# Altium schematic sheet H_bridge.SchDoc
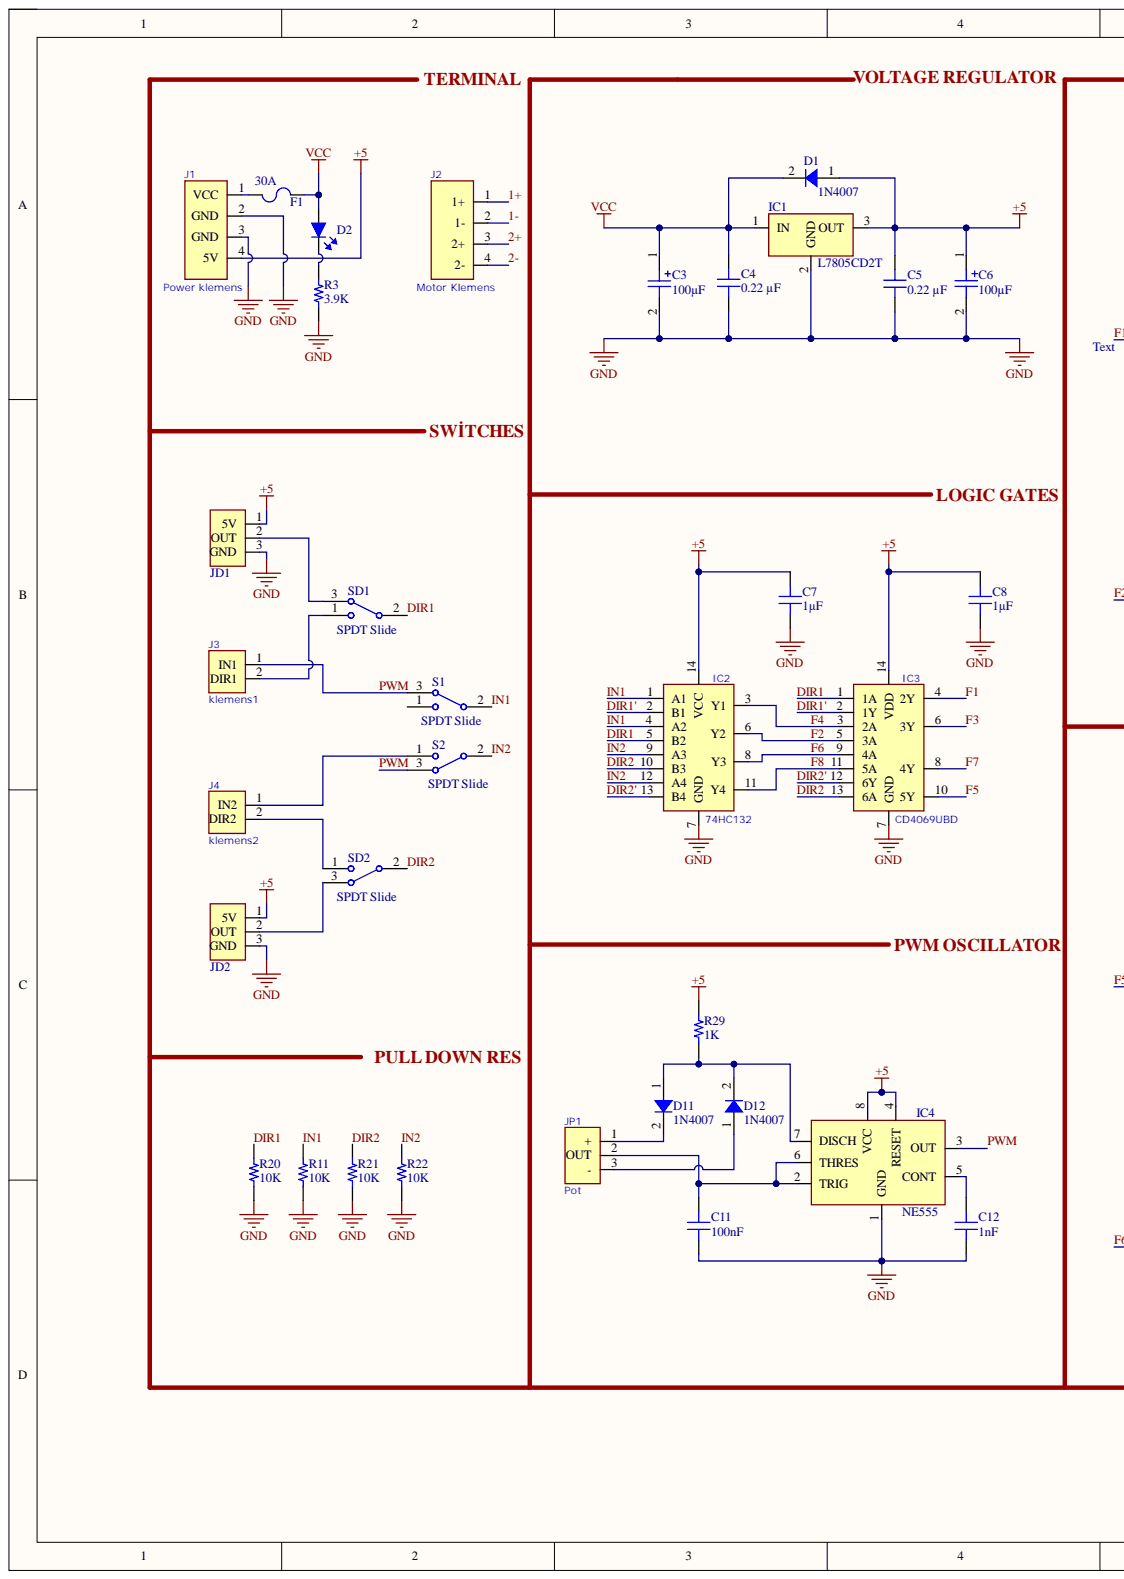
[diagram p0: H_bridge.SchDoc, left]
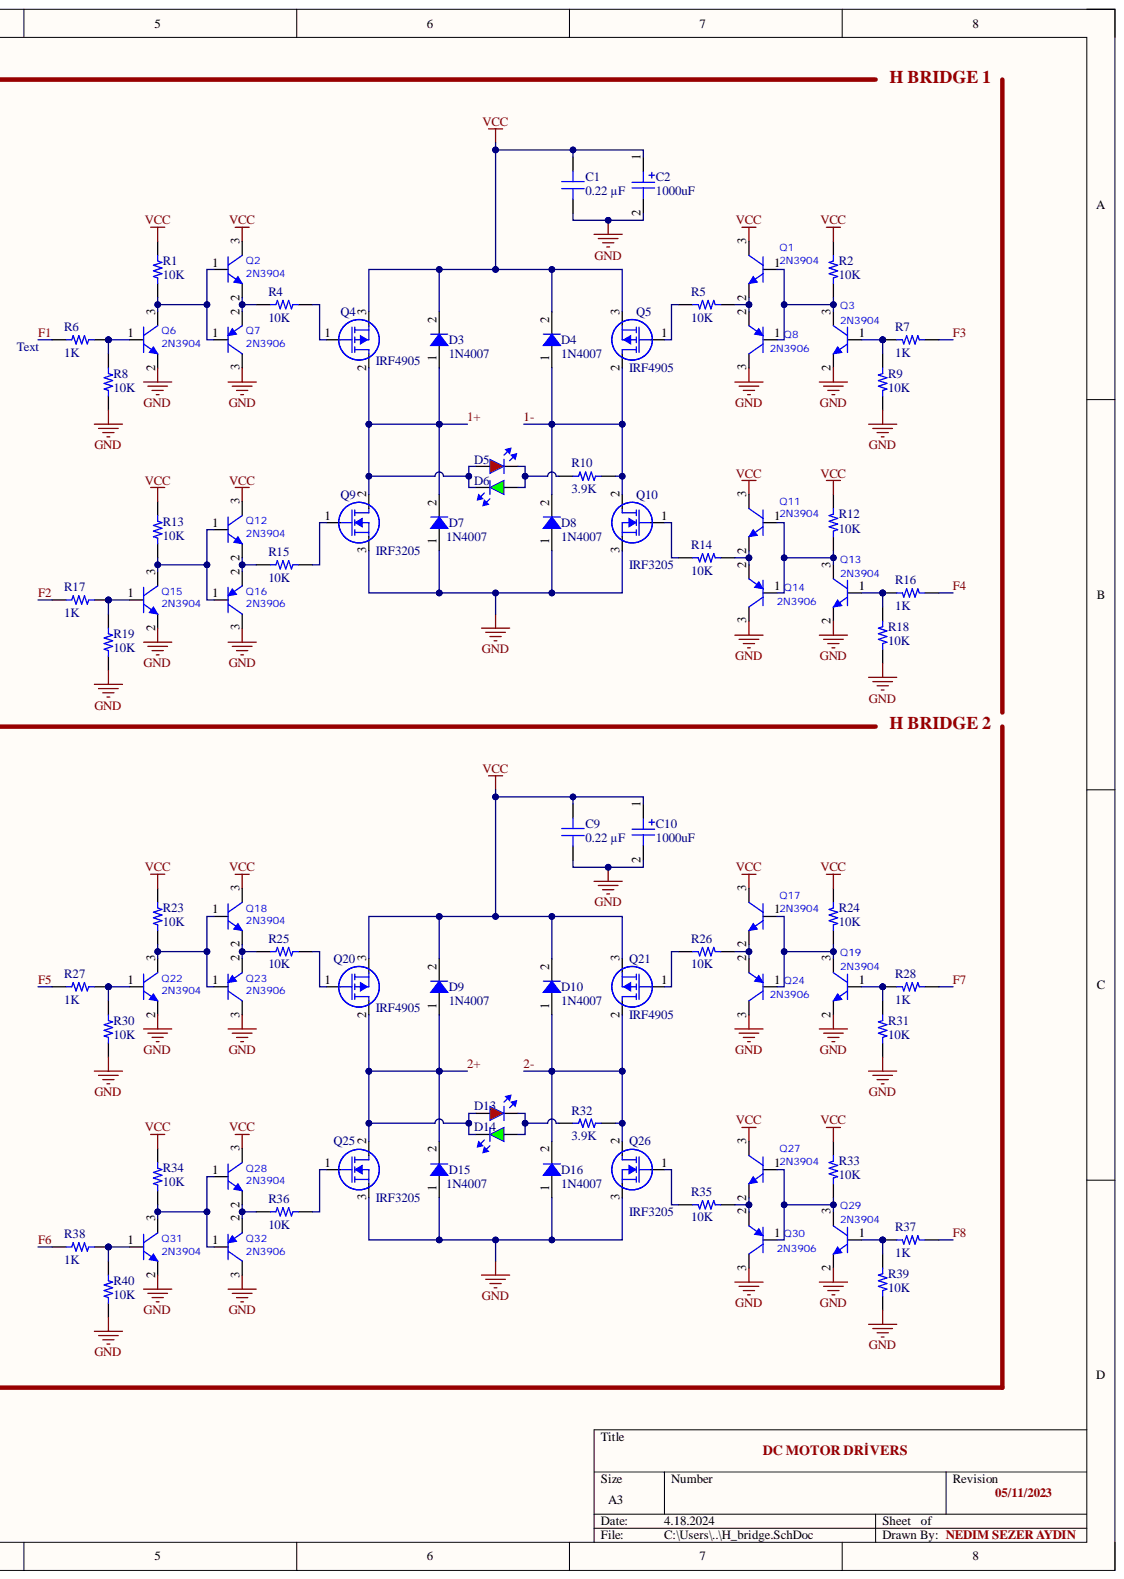
[diagram p1: H_bridge.SchDoc, right]

## Parts
C1  0.22 µF  [CAP 1210_3225 - 1MM]
C2  1000uF  [35V 1000uF]
C3  100µF  [Aluminum Cap]
C4  0.22 µF  [CAP 1210_3225 - 1MM]
C5  0.22 µF  [CAP 1210_3225 - 1MM]
C6  100µF  [Aluminum Cap]
C7  1µF  [CAP 1210_3225 - 1.4MM]
C8  1µF  [CAP 1210_3225 - 1.4MM]
C9  0.22 µF  [CAP 1210_3225 - 1MM]
C10  1000uF  [35V 1000uF]
C11  100nF  [CAP 1210_3225 - 1MM]
C12  1nF  [CAP 1210_3225 - 1MM]
D1  1N4007  [DO214AC SMA]
D2  (no value)  [LED_W]
D3  1N4007  [DO214AC SMA]
D4  1N4007  [DO214AC SMA]
D5  (no value)  [LED_RED]
D6  (no value)  [LED_GREEN]
D7  1N4007  [DO214AC SMA]
D8  1N4007  [DO214AC SMA]
D9  1N4007  [DO214AC SMA]
D10  1N4007  [DO214AC SMA]
D11  1N4007  [DO214AC SMA]
D12  1N4007  [DO214AC SMA]
D13  (no value)  [LED_RED]
D14  (no value)  [LED_GREEN]
D15  1N4007  [DO214AC SMA]
D16  1N4007  [DO214AC SMA]
F1  30A  [FUSE 1206_3216 0.6MM]
IC1  L7805CD2T  [L7805CD2T]
IC2  74HC132  [SOIC14]
IC3  CD4069UBD  [SOIC14]
IC4  NE555  [NE5532-SOIC8]
J1  Power klemens  [Power Klemens]
J2  Motor Klemens  [Power Klemens]
J3  klemens1  [Klemens]
J4  klemens2  [Klemens]
JD1  Switch  [JST-XH 2.54]  (value not drawn)
JD2  Switch  [JST-XH 2.54]  (value not drawn)
JP1  Pot  [JST-XH 2.54]
Q1  2N3904  [SOT23-3]
Q2  2N3904  [SOT23-3]
Q3  2N3904  [SOT23-3]
Q4  IRF4905  [IRF4905]
Q5  IRF4905  [IRF4905]
Q6  2N3904  [SOT23-3]
Q7  2N3906  [SOT23-3]
Q8  2N3906  [SOT23-3]
Q9  IRF3205  [IRF3205S]
Q10  IRF3205  [IRF3205S]
Q11  2N3904  [SOT23-3]
Q12  2N3904  [SOT23-3]
Q13  2N3904  [SOT23-3]
Q14  2N3906  [SOT23-3]
Q15  2N3904  [SOT23-3]
Q16  2N3906  [SOT23-3]
Q17  2N3904  [SOT23-3]
Q18  2N3904  [SOT23-3]
Q19  2N3904  [SOT23-3]
Q20  IRF4905  [IRF4905]
Q21  IRF4905  [IRF4905]
Q22  2N3904  [SOT23-3]
Q23  2N3906  [SOT23-3]
Q24  2N3906  [SOT23-3]
Q25  IRF3205  [IRF3205S]
Q26  IRF3205  [IRF3205S]
Q27  2N3904  [SOT23-3]
Q28  2N3904  [SOT23-3]
Q29  2N3904  [SOT23-3]
Q30  2N3906  [SOT23-3]
Q31  2N3904  [SOT23-3]
Q32  2N3906  [SOT23-3]
R1  10K  [RES 1210_3225]
R2  10K  [RES 1210_3225]
R3  3.9K  [RES 1206_3216]
R4  10K  [RES 1210_3225]
R5  10K  [RES 1210_3225]
R6  1K  [RES 1206_3216]
R7  1K  [RES 1206_3216]
R8  10K  [RES 1206_3216]
R9  10K  [RES 1206_3216]
R10  3.9K  [RES 1206_3216]
R11  10K  [RES 1206_3216]
R12  10K  [RES 1210_3225]
R13  10K  [RES 1210_3225]
R14  10K  [RES 1210_3225]
R15  10K  [RES 1210_3225]
R16  1K  [RES 1206_3216]
R17  1K  [RES 1206_3216]
R18  10K  [RES 1206_3216]
R19  10K  [RES 1206_3216]
R20  10K  [RES 1206_3216]
R21  10K  [RES 1206_3216]
R22  10K  [RES 1206_3216]
R23  10K  [RES 1210_3225]
R24  10K  [RES 1210_3225]
R25  10K  [RES 1210_3225]
R26  10K  [RES 1210_3225]
R27  1K  [RES 1206_3216]
R28  1K  [RES 1206_3216]
R29  1K  [RES 1206_3216]
R30  10K  [RES 1206_3216]
R31  10K  [RES 1206_3216]
R32  3.9K  [RES 1206_3216]
R33  10K  [RES 1210_3225]
R34  10K  [RES 1210_3225]
R35  10K  [RES 1210_3225]
R36  10K  [RES 1210_3225]
R37  1K  [RES 1206_3216]
R38  1K  [RES 1206_3216]
R39  10K  [RES 1206_3216]
R40  10K  [RES 1206_3216]
S1  SPDT Slide  [SPDT]
S2  SPDT Slide  [SPDT]
SD1  SPDT Slide  [SPDT]
SD2  SPDT Slide  [SPDT]

## Nets
+5: C5.2 C6.1 C7.2 C8.2 D1.1 IC1.3 IC2.14(VCC) IC3.14(VDD) IC4.4(RESET) IC4.8(VCC) J1.4(5V) JD1.1 JD2.1 R29.2
GND: C1.1 C2.2 C3.2 C4.1 C5.1 C6.2 C7.1 C8.1 C9.1 C10.2 C11.1 C12.1 D7.1 D8.1 D15.1 D16.1 IC1.2 IC2.7(GND) IC3.7(GND) IC4.1(GND) J1.2(GND) J1.3(GND) JD1.3 JD2.3 Q3.2 Q6.2 Q7.3 Q8.3 Q9.3 Q10.3 Q13.2 Q14.3 Q15.2 Q16.3 Q19.2 Q22.2 Q23.3 Q24.3 Q25.3 Q26.3 Q29.2 Q30.3 Q31.2 Q32.3 R3.1 R8.1 R9.1 R11.1 R18.1 R19.1 R20.1 R21.1 R22.1 R30.1 R31.1 R39.1 R40.1
VCC: C1.2 C2.1 C4.2 C9.2 C10.1 D1.2 D2.1 D3.2 D4.2 D9.2 D10.2 F1.2 IC1.1 Q1.3 Q2.3 Q4.3 Q5.3 Q11.3 Q12.3 Q17.3 Q18.3 Q20.3 Q21.3 Q27.3 Q28.3 R1.1 R2.1 R12.1 R13.1 R23.1 R24.1 R33.1 R34.1
1+: D3.1 D5.1 D6.2 D7.2 J2.1(1+) Q4.2 Q9.2
1-: D4.1 D8.2 J2.2(1-) Q5.2 Q10.2 R10.1
2+: D9.1 D13.1 D14.2 D15.2 J2.3(2+) Q20.2 Q25.2
2-: D10.1 D16.2 J2.4(2-) Q21.2 Q26.2 R32.1
DIR1: IC2.5(B2) IC3.1(1A) R20.2 SD1.2
DIR1': IC2.2(B1) IC3.2(1Y)
DIR2: IC2.10(B3) IC3.13(6A) R21.2 SD2.2
DIR2': IC2.13(B4) IC3.12(6Y)
F1: IC3.4(2Y) R6.1
F2: IC2.6(Y2) IC3.5(3A) R17.1
F3: IC3.6(3Y) R7.1
F4: IC2.3(Y1) IC3.3(2A) R16.1
F5: IC3.10(5Y) R27.1
F6: IC2.8(Y3) IC3.9(4A) R38.1
F7: IC3.8(4Y) R28.1
F8: IC2.11(Y4) IC3.11(5A) R37.1
IN1: IC2.1(A1) IC2.4(A2) R11.2 S1.2
IN2: IC2.9(A3) IC2.12(A4) R22.2 S2.2
PWM: IC4.3(OUT) J3.1 S1.3 S2.3
NetC11_2: C11.2 IC4.2(TRIG) IC4.6(THRES) JP1.2
NetC12_2: C12.2 IC4.5(CONT)
NetD2_2: D2.2 R3.2
NetD5_2: D5.2 D6.1 R10.2
NetD11_1: D11.1 D12.2 IC4.7(DISCH) R29.1
NetD11_2: D11.2 JP1.1
NetD12_1: D12.1 JP1.3
NetD13_2: D13.2 D14.1 R32.2
NetF1_1: F1.1 J1.1(VCC)
NetJ3_2: J3.2 SD1.1
NetJ4_1: J4.1 S2.1
NetJ4_2: J4.2 SD2.1
NetJD1_2: JD1.2 SD1.3
NetJD2_2: JD2.2 SD2.3
NetQ1_1: Q1.1 Q3.3 Q8.1 R2.2
NetQ1_2: Q1.2 Q8.2 R5.1
NetQ2_1: Q2.1 Q6.3 Q7.1 R1.2
NetQ2_2: Q2.2 Q7.2 R4.1
NetQ3_1: Q3.1 R7.2 R9.2
NetQ4_1: Q4.1 R4.2
NetQ5_1: Q5.1 R5.2
NetQ6_1: Q6.1 R6.2 R8.2
NetQ9_1: Q9.1 R15.2
NetQ10_1: Q10.1 R14.2
NetQ11_1: Q11.1 Q13.3 Q14.1 R12.2
NetQ11_2: Q11.2 Q14.2 R14.1
NetQ12_1: Q12.1 Q15.3 Q16.1 R13.2
NetQ12_2: Q12.2 Q16.2 R15.1
NetQ13_1: Q13.1 R16.2 R18.2
NetQ15_1: Q15.1 R17.2 R19.2
NetQ17_1: Q17.1 Q19.3 Q24.1 R24.2
NetQ17_2: Q17.2 Q24.2 R26.1
NetQ18_1: Q18.1 Q22.3 Q23.1 R23.2
NetQ18_2: Q18.2 Q23.2 R25.1
NetQ19_1: Q19.1 R28.2 R31.2
NetQ20_1: Q20.1 R25.2
NetQ21_1: Q21.1 R26.2
NetQ22_1: Q22.1 R27.2 R30.2
NetQ25_1: Q25.1 R36.2
NetQ26_1: Q26.1 R35.2
NetQ27_1: Q27.1 Q29.3 Q30.1 R33.2
NetQ27_2: Q27.2 Q30.2 R35.1
NetQ28_1: Q28.1 Q31.3 Q32.1 R34.2
NetQ28_2: Q28.2 Q32.2 R36.1
NetQ29_1: Q29.1 R37.2 R39.2
NetQ31_1: Q31.1 R38.2 R40.2

Not connected: C3 1; S1 1

## Library data of the parts
C1 C4 C5 C9 = CGA6M3X7R2E224M200AE: CAP CER 0.22UF 250V X7R 1210; manufacturer: TDK Corporation; Database Table Name: Capacitors - Ceramic - 1210; Tolerance: ±20%; Applications: Automotive, Boardflex Sensitive; Capacitance: 0.22 µF; Component Kind: Standard; componentlink1description: Datasheet; componentlink1url: https://product.tdk.com/info/en/catalog/datasheets/mlcc_automotive_soft_en.pdf?ref_disty=digikey; componentlink2description: DigiKey Link; componentlink2url: https://www.digikey.com/en/products/detail/tdk-corporation/CGA6M3X7R2E224M200AE/2782510; Device Package: 1210 (3225 Metric); Features: Soft Termination; lastupdated: 5.12.2023 20:39:24; Library Name: Celestial Altium Library - altium_library.DbLib; Lifecycle Status: Active; Minimum Order: 1; Mounting Type: Surface Mount, MLCC; Operating Temperature: -55°C ~ 125°C; Package / Case: 1210 (3225 Metric); packaging: TapeAndReel; Part Number: CGA6M3X7R2E224M200AE; Part Status: Active; partid: 24380; price: 0.19; Ratings: AEC-Q200; series: CGA; Size / Dimension: 0.126" L x 0.098" W (3.20mm x 2.50mm); Supplier 1: DigiKey; Supplier Part Number 1: 445-7987-1-ND; Temperature Coefficient: X7R; Thickness (Max): 0.091" (2.30mm); Value: 0.22 µF; Voltage - Rated: 250V
C2 C10 = 35V 1000uF: Component Kind: Standard; Library Name: AMP.SchLib
C3 C6 = Aluminum Cap: Component Kind: Standard; Library Name: AMP.SchLib
C7 C8 = 12101U102KAT2A: CAP CER 1000PF 100V NP0 1210; manufacturer: AVX Corporation; Database Table Name: Capacitors - Ceramic - 1210; Temperature Coefficient: C0G, NP0; Tolerance: ±10%; Applications: RF, Microwave, High Frequency; Capacitance: 1000pF; Component Kind: Standard; componentlink1description: Datasheet; componentlink1url: http://datasheets.avx.com/U-Series.pdf; componentlink2description: DigiKey Link; componentlink2url: http://digikey.com/product-detail/en/avx-corporation/12101U102KAT2A/478-11969-1-ND/8573605; Device Package: 1210 (3225 Metric); Features: Ultra Low ESR; lastupdated: 2.09.2020 01:10:31; Library Name: Celestial Altium Library - altium_library.DbLib; Lifecycle Status: Active; Minimum Order: 1; Mounting Type: Surface Mount, MLCC; Operating Temperature: -55°C ~ 125°C; Package / Case: 1210 (3225 Metric); packaging: TapeAndReel; Part Number: 12101U102KAT2A; Part Status: Active; partid: 25016; price: 0.50; series: U; Size / Dimension: 0.126" L x 0.098" W (3.20mm x 2.49mm); Supplier 1: DigiKey; Supplier Part Number 1: 478-11969-1-ND; Thickness (Max): 0.055" (1.40mm); Value: 1µF; Voltage - Rated: 100V
C11 = C1210C102JDGACAUTO: CAP CER 1210 1NF 1000V C0G 5%; manufacturer: KEMET; Database Table Name: Capacitors - Ceramic - 1210; Temperature Coefficient: C0G, NP0; Voltage - Rated: 1000V (1kV); Applications: Automotive; Capacitance: 100nF; Component Kind: Standard; componentlink1description: Datasheet; componentlink1url: https://api.kemet.com/component-edge/download/datasheet/C1210C102JDGACAUTO.pdf; componentlink2description: DigiKey Link; componentlink2url: https://www.digikey.com/en/products/detail/kemet/C1210C102JDGACAUTO/8109921; Device Package: 1210 (3225 Metric); Features: Low ESL, High Voltage; lastupdated: 5.12.2023 20:39:33; Library Name: Celestial Altium Library - altium_library.DbLib; Lifecycle Status: Active; Minimum Order: 1; Mounting Type: Surface Mount, MLCC; Operating Temperature: -55°C ~ 125°C; Package / Case: 1210 (3225 Metric); packaging: TapeAndReel; Part Number: C1210C102JDGACAUTO; Part Status: Active; partid: 43709; price: 0.57; Ratings: AEC-Q200; series: SMD Auto C0G HV; Size / Dimension: 0.126" L x 0.098" W (3.20mm x 2.50mm); Supplier 1: DigiKey; Supplier Part Number 1: 399-16740-1-ND; Thickness (Max): 0.075" (1.90mm); Tolerance: ±5%; Value: 100nF
C12 = C1210C102JDGACAUTO: CAP CER 1210 1NF 1000V C0G 5%; manufacturer: KEMET; Capacitance: 1000 pF; Database Table Name: Capacitors - Ceramic - 1210; Temperature Coefficient: C0G, NP0; Voltage - Rated: 1000V (1kV); Applications: Automotive; Component Kind: Standard; componentlink1description: Datasheet; componentlink1url: https://api.kemet.com/component-edge/download/datasheet/C1210C102JDGACAUTO.pdf; componentlink2description: DigiKey Link; componentlink2url: https://www.digikey.com/en/products/detail/kemet/C1210C102JDGACAUTO/8109921; Device Package: 1210 (3225 Metric); Features: Low ESL, High Voltage; lastupdated: 5.12.2023 20:39:33; Library Name: Celestial Altium Library - altium_library.DbLib; Lifecycle Status: Active; Minimum Order: 1; Mounting Type: Surface Mount, MLCC; Operating Temperature: -55°C ~ 125°C; Package / Case: 1210 (3225 Metric); packaging: TapeAndReel; Part Number: C1210C102JDGACAUTO; Part Status: Active; partid: 43709; price: 0.57; Ratings: AEC-Q200; series: SMD Auto C0G HV; Size / Dimension: 0.126" L x 0.098" W (3.20mm x 2.50mm); Supplier 1: DigiKey; Supplier Part Number 1: 399-16740-1-ND; Thickness (Max): 0.075" (1.90mm); Tolerance: ±5%; Value: 1nF
D1 D3 D4 D7-D12 D15 D16 = US1D-TP: DIODE GEN PURP 200V 1A DO214AC; Manufacturer: Micro Commercial Co; Capacitance @ Vr, F: 20pF @ 4V, 1MHz; Current - Reverse Leakage @ Vr: 10 µA @ 200 V; Database Table Name: Diodes - Rectifiers - Single; Diode Type: Standard; Reverse Recovery Time (trr): 50 ns; Speed: Fast Recovery =< 500ns, > 200mA (Io); Voltage - Forward (Vf) (Max) @ If: 1 V @ 1 A; Component Kind: Standard; ComponentLink1Description: Datasheet; ComponentLink1URL: https://www.mccsemi.com/pdf/Products/US1A-US1M(DO-214AC).pdf; ComponentLink2Description: DigiKey Link; ComponentLink2URL: https://www.digikey.com/en/products/detail/micro-commercial-co/US1D-TP/1793280; Current - Average Rectified (Io): 1A; LastUpdated: 5.12.2023 21:20:29; Library Name: Celestial Altium Library - altium_library.DbLib; Lifecycle Status: Active; Minimum Order: 1; Mounting Type: Surface Mount; Operating Temperature - Junction: -65°C ~ 175°C; Package / Case: DO-214AC, SMA; Packaging: TapeAndReel; Part Number: US1D-TP; Part Status: Active; PartId: 586; Price: 0.04; Series: -; Supplier 1: DigiKey; Supplier Device Package: DO-214AC (SMA); Supplier Part Number 1: US1D-TPMSCT-ND; Voltage - DC Reverse (Vr) (Max): 200 V
D2 = LED_BLUE: Component Kind: Standard; Library Name: AMP.SchLib
D5 D13 = LED_RED: Component Kind: Standard; Library Name: AMP.SchLib
D6 D14 = LED_GREEN: Component Kind: Standard; Library Name: AMP.SchLib
F1 = 3413.0120.22: FUSE BOARD MNT 2.5A 32VAC 63VDC; Manufacturer: Schurter Inc.; Approval Agency: cURus; Breaking Capacity @ Rated Voltage: 50A; Database Table Name: Fuses - Fuse; Fuse Type: Board Mount (Cartridge Style Excluded); Melting I²t: 0.35; Response Time: Fast Blow; Voltage Rating - AC: 32V; Voltage Rating - DC: 63V; Approvals: cURus; Component Kind: Standard; ComponentLink1Description: Datasheet; ComponentLink1URL: http://www.schurterinc.com/bundles/snceschurter/epim/_ProdPool_/newDS/en/typ_USF_1206.pdf; ComponentLink2Description: DigiKey Link; ComponentLink2URL: http://digikey.com/product-detail/en/schurter-inc/3413.0120.22/486-1681-1-ND/2870086; Current Rating (Amps): 2.5A; Device Package: 1206 (3216 Metric); LastUpdated: 2.09.2020 01:30:41; Library Name: Celestial Altium Library - altium_library.DbLib; Lifecycle Status: Active; Minimum Order: 1; Mounting Type: Surface Mount; Operating Temperature: -55°C ~ 90°C; Package / Case: 1206 (3216 Metric); Packaging: TapeAndReel; Part Number: 3413.0120.22; Part Status: Active; Price: 0.24; Series: USF 1206; Size / Dimension: 0.126" L x 0.063" W x 0.024" H (3.20mm x 1.60mm x 0.60mm); Supplier 1: DigiKey; Supplier Part Number 1: 486-1681-1-ND
Q9 Q10 Q25 Q26 = IRF3205S: Component Kind: Standard; Library Name: AMP.SchLib
R1 R2 R4 R5 R12-R15 R23-R26 R33-R36 = RMCF1210FT10K0: RES 10K OHM 1% 1/2W 1210; Manufacturer: Stackpole Electronics Inc; Database Table Name: Resistors - Surface Mount - 1210; Power (Watts): 0.5W, 1/2W; Resistance: 10 kOhms; Temperature Coefficient: ±100ppm/°C; Value: 10 kOhms; Component Kind: Standard; ComponentLink1Description: Datasheet; ComponentLink1URL: https://www.seielect.com/catalog/sei-rmcf_rmcp.pdf; ComponentLink2Description: DigiKey Link; ComponentLink2URL: https://www.digikey.com/en/products/detail/stackpole-electronics-inc/RMCF1210FT10K0/1758528; Composition: Thick Film; Device Package: 1210 (3225 Metric); Features: Automotive AEC-Q200; Height - Seated (Max): 0.028" (0.70mm); LastUpdated: 5.12.2023 21:42:02; Library Name: Celestial Altium Library - altium_library.DbLib; Lifecycle Status: Active; Minimum Order: 1; Number of Terminations: 2; Operating Temperature: -55°C ~ 155°C; Package / Case: 1210 (3225 Metric); Packaging: TapeAndReel; Part Number: RMCF1210FT10K0; Part Status: Active; Price: 0.01; Ratings: AEC-Q200; Series: RMCF; Size / Dimension: 0.126" L x 0.098" W (3.20mm x 2.50mm); Supplier 1: DigiKey; Supplier Device Package: 1210; Supplier Part Number 1: RMCF1210FT10K0CT-ND; Tolerance: ±1%
R3 R10 R32 = AC1206JR-073K9L: RES SMD 3.9K OHM 5% 1/4W 1206; Manufacturer: Yageo; Database Table Name: Resistors - Surface Mount - 1206; Power (Watts): 0.25W, 1/4W; Resistance: 3.9 kOhms; Temperature Coefficient: ±100ppm/°C; Value: 3.9 kOhms; Component Kind: Standard; ComponentLink1Description: Datasheet; ComponentLink1URL: https://www.yageo.com/upload/media/product/productsearch/datasheet/rchip/PYu-AC_51_RoHS_L_7.pdf; ComponentLink2Description: DigiKey Link; ComponentLink2URL: https://www.digikey.com/en/products/detail/yageo/AC1206JR-073K9L/5897848; Composition: Thick Film; Device Package: 1206 (3216 Metric); Features: Automotive AEC-Q200, Moisture Resistant; Height - Seated (Max): 0.026" (0.65mm); LastUpdated: 5.12.2023 20:47:59; Library Name: Celestial Altium Library - altium_library.DbLib; Lifecycle Status: Active; Minimum Order: 1; Number of Terminations: 2; Operating Temperature: -55°C ~ 155°C; Package / Case: 1206 (3216 Metric); Packaging: TapeAndReel; Part Number: AC1206JR-073K9L; Part Status: Active; PartId: 27123; Price: 0.01; Ratings: AEC-Q200; Series: AC; Size / Dimension: 0.122" L x 0.063" W (3.10mm x 1.60mm); Supplier 1: DigiKey; Supplier Device Package: 1206; Supplier Part Number 1: YAG3959CT-ND; Tolerance: ±5%
R6 R7 R16 R17 R27-R29 R37 R38 = RC1206FR-071KL: RES 1K OHM 1% 1/4W 1206; Manufacturer: Yageo; Database Table Name: Resistors - Surface Mount - 1206; Power (Watts): 0.25W, 1/4W; Resistance: 1 kOhms; Temperature Coefficient: ±100ppm/°C; Value: 1 kOhms; Component Kind: Standard; ComponentLink1Description: Datasheet; ComponentLink1URL: https://www.yageo.com/upload/media/product/productsearch/datasheet/rchip/PYu-RC_Group_51_RoHS_L_10.pdf; ComponentLink2Description: DigiKey Link; ComponentLink2URL: https://www.digikey.com/en/products/detail/yageo/RC1206FR-071KL/728387; Composition: Thick Film; Device Package: 1206 (3216 Metric); Features: Moisture Resistant; Height - Seated (Max): 0.026" (0.65mm); LastUpdated: 5.12.2023 20:48:00; Library Name: Celestial Altium Library - altium_library.DbLib; Lifecycle Status: Active; Minimum Order: 1; Number of Terminations: 2; Operating Temperature: -55°C ~ 155°C; Package / Case: 1206 (3216 Metric); Packaging: TapeAndReel; Part Number: RC1206FR-071KL; Part Status: Active; PartId: 26978; Price: 0.01; Series: RC_L; Size / Dimension: 0.122" L x 0.063" W (3.10mm x 1.60mm); Supplier 1: DigiKey; Supplier Device Package: 1206; Supplier Part Number 1: 311-1.00KFRCT-ND; Tolerance: ±1%
R8 R9 R11 R18-R22 R30 R31 R39 R40 = CRG1206F10K: RES SMD 10K OHM 1% 1/4W 1206; Manufacturer: TE Connectivity Passive Product; Database Table Name: Resistors - Surface Mount - 1206; Power (Watts): 0.25W, 1/4W; Resistance: 10 kOhms; Temperature Coefficient: ±100ppm/°C; Value: 10 kOhms; Component Kind: Standard; ComponentLink1Description: Datasheet; ComponentLink1URL: https://www.te.com/commerce/DocumentDelivery/DDEController?Action=srchrtrv&DocNm=1773204&DocType=DS&DocLang=English; ComponentLink2Description: DigiKey Link; ComponentLink2URL: https://www.digikey.com/en/products/detail/te-connectivity-passive-product/CRG1206F10K/2389909; Composition: Thick Film; Device Package: 1206 (3216 Metric); Height - Seated (Max): 0.026" (0.65mm); Library Name: Celestial Altium Library - altium_library.DbLib; Lifecycle Status: Active; Minimum Order: 1; Number of Terminations: 2; Operating Temperature: -55°C ~ 155°C; Package / Case: 1206 (3216 Metric); Packaging: TapeAndReel; Part Number: CRG1206F10K; Part Status: Active; PartId: 26989; Price: 0.01; Series: CRG, Neohm; Size / Dimension: 0.122" L x 0.061" W (3.10mm x 1.55mm); Supplier 1: DigiKey; Supplier Device Package: 1206; Supplier Part Number 1: A106069CT-ND; Tolerance: ±1%
  R8 R9 R18 R19 R30 R31 R39 R40: LastUpdated: 5.12.2023 20:48:00
  R11 R20-R22: LastUpdated: 7.12.2023 20:50:34
IC1 = L7805CD2T: Component Kind: Standard; Library Name: AMP.SchLib
IC2 = 74HC132: Component Kind: Standard; Library Name: AMP.SchLib
IC3 = CD4069UBD: Component Kind: Standard; Library Name: AMP.SchLib
IC4 = NE555: Component Kind: Standard; Library Name: AMP.SchLib
J1 = Power klemens: Component Kind: Standard; Library Name: AMP.SchLib
J2 = Motor Klemens: Component Kind: Standard; Library Name: AMP.SchLib
J3 = klemens1: Component Kind: Standard; Library Name: AMP.SchLib
J4 = klemens2: Component Kind: Standard; Library Name: AMP.SchLib
JD1 JD2 = switch: Component Kind: Standard; Library Name: AMP.SchLib
JP1 = Pot: Component Kind: Standard; Library Name: AMP.SchLib
Q1-Q3 Q6 Q11-Q13 Q15 Q17-Q19 Q22 Q27-Q29 Q31 = 2N3904: Component Kind: Standard; Library Name: AMP.SchLib
Q4 Q5 Q20 Q21 = IRF4905: Component Kind: Standard; Library Name: AMP.SchLib
Q7 Q8 Q14 Q16 Q23 Q24 Q30 Q32 = 2N3906: Component Kind: Standard; Library Name: AMP.SchLib
S1 S2 SD1 SD2 = SPDT Slide: Component Kind: Standard; Library Name: AMP.SchLib
